# Altium schematic sheet CAN.SchDoc(CAN)
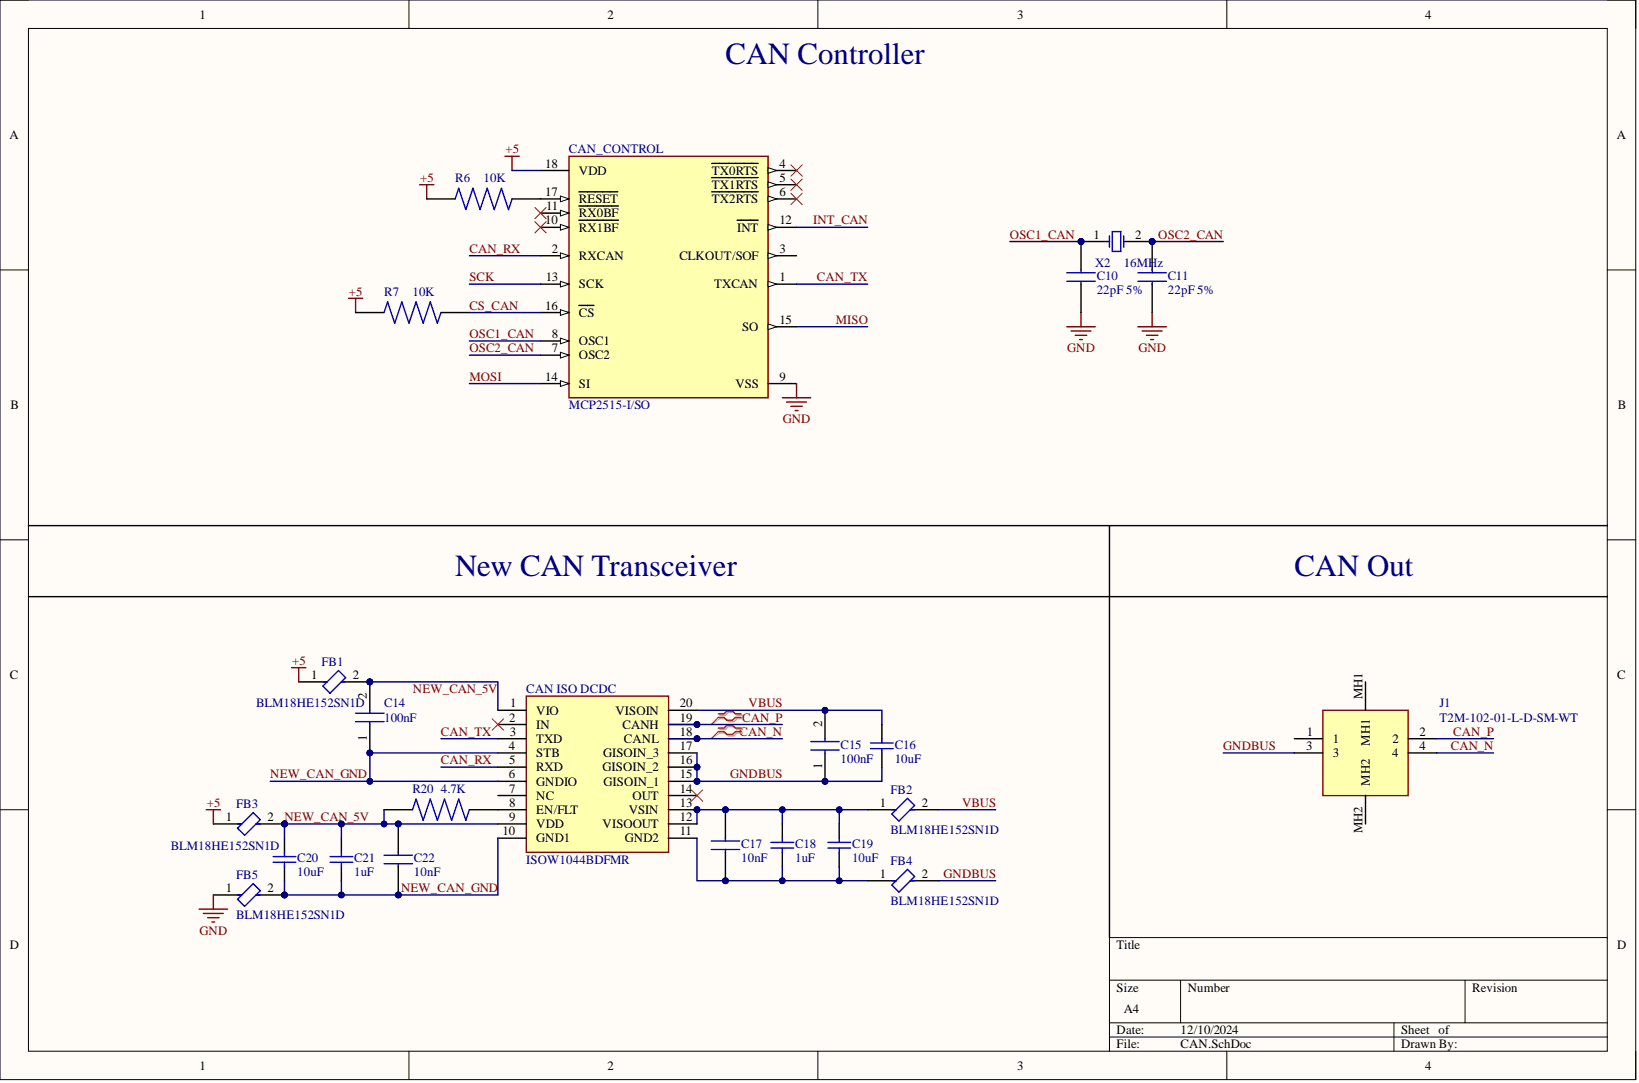
[diagram p0: CAN.SchDoc]

## Parts
C10  22pF 5%
C11  22pF 5%
C14  100nF
C15  100nF
C16  10uF
C17  10nF
C18  1uF
C19  10uF
C20  10uF
C21  1uF
C22  10nF
CAN_ISO_DCDC  ISOW1044BDFMR
CAN_CONTROL  MCP2515-I/SO
FB1  BLM18HE152SN1D
FB2  BLM18HE152SN1D
FB3  BLM18HE152SN1D
FB4  BLM18HE152SN1D
FB5  BLM18HE152SN1D
J1  T2M-102-01-L-D-SM-WT
R6  10K
R7  10K
R20  4.7K
X2  16MHz

## Nets
+5: CAN_CONTROL.18(VDD) FB1.1 FB3.1 R6.1 R7.1
GND: C10.1 C11.1 CAN_CONTROL.9(VSS) FB5.1
CAN_N: CAN_ISO_DCDC.18(CANL) J1.4
CAN_P: CAN_ISO_DCDC.19(CANH) J1.2
CAN_RX: CAN_ISO_DCDC.5(RXD) CAN_CONTROL.2(RXCAN)
CAN_TX: CAN_ISO_DCDC.3(TXD) CAN_CONTROL.1(TXCAN)
CS_CAN: CAN_CONTROL.16(CS) R7.2
GNDBUS: C15.1 C16.1 CAN_ISO_DCDC.15(GISOIN_1) CAN_ISO_DCDC.16(GISOIN_2) CAN_ISO_DCDC.17(GISOIN_3) FB4.2 J1.3
INT_CAN: CAN_CONTROL.12(INT)
MISO: CAN_CONTROL.15(SO)
MOSI: CAN_CONTROL.14(SI)
NEW_CAN_5V: C14.2 C20.2 C21.2 C22.2 CAN_ISO_DCDC.1(VIO) CAN_ISO_DCDC.9(VDD) FB1.2 FB3.2 R20.1
NEW_CAN_GND: C14.1 C20.1 C21.1 C22.1 CAN_ISO_DCDC.4(STB) CAN_ISO_DCDC.6(GNDIO) CAN_ISO_DCDC.10(GND1) FB5.2
OSC1_CAN: C10.2 CAN_CONTROL.8(OSC1) X2.1
OSC2_CAN: C11.2 CAN_CONTROL.7(OSC2) X2.2
SCK: CAN_CONTROL.13(SCK)
VBUS: C15.2 C16.2 CAN_ISO_DCDC.20(VISOIN) FB2.2
NetC17_1: C17.1 C18.1 C19.1 CAN_ISO_DCDC.11(GND2) FB4.1
NetC17_2: C17.2 C18.2 C19.2 CAN_ISO_DCDC.12(VISOOUT) CAN_ISO_DCDC.13(VSIN) FB2.1
NetCAN ISO DCDC_8: CAN_ISO_DCDC.8(EN/FLT) R20.2
NetCAN_CONTROL_17: CAN_CONTROL.17(RESET) R6.2

Not connected: CAN_ISO_DCDC 2(IN) 7(NC) 14(OUT); CAN_CONTROL 3(CLKOUT/SOF) 4(TX0RTS) 5(TX1RTS) 6(TX2RTS) 10(RX1BF) 11(RX0BF); J1 1 MH1 MH2

## Library data of the parts
C10 C11 = CMP-015-000000-3: 885012007053 Multilayer Ceramic Capacitors MLCC - SMD/SMT WCAP-CSGP 22pF 0805 5% 50V MLCC; Manufacturer_Name: Wurth Elektronik; Geometry.Height: 0.7mm; Component Kind: Standard; Datasheet Link: https://katalog.we-online.com/pbs/datasheet/885012007053.pdf; Design Item ID: 885012007053; Manufacturer_Part_Number: 885012007053; Mouser Part Number: 710-885012007053; Mouser Price/Stock: https://www.mouser.co.uk/ProductDetail/Wurth-Elektronik/885012007053?qs=0KOYDY2FL285f7xXUJLtKA%3D%3D; Source: 885012007053.SchLib; Library Name: BumbleBee Autonomous Systems - NUS
C14 C15 = CMP-061-000001-1: Capacitor; Manufacturer_Name: Wurth Elektronik; Manufacturer_Part_Number: 885012207098R; Component Kind: Standard; Datasheet Link: https://www.we-online.com/en/components/products/WCAP-CSGP-50VDC#885012207098R; Design Item ID: 885012207098R; Height: 0.9mm; Source: SamacSys.SchLib; Library Name: BumbleBee Autonomous Systems - NUS
C16 C19 C20 = CMP-00066-1: Cap, Mlcc, X7r, 10Uf, 6.3V, 0805; Tolerance: 10%; Case Code (Imperial): 0805; Case Code (Metric): 2012; Case/Package: 0805; Component Kind: Standard; Length: 0.079inch; Max Operating Temperature: 125°C; Min Operating Temperature: -55°C; Mounting Technology: Surface Mount; Packaging: Cut Tape; RoHS Compliant: Yes; Series: WCAP-CSGP; Thickness: 0.057inch; Value: 10uF; Voltage Rating: 6.3V; Width: 0.049inch; Library Name: BumbleBee Autonomous Systems - NUS
C17 C22 = CMP-012-000000-2: 885382207006 Multilayer Ceramic Capacitors MLCC - SMD/SMT WCAP-CSST 0805 10nF 10% 50VDC; Manufacturer_Name: Wurth Elektronik; Geometry.Height: 1.35mm; Component Kind: Standard; Datasheet Link: https://katalog.we-online.com/pbs/datasheet/885382207006.pdf; Design Item ID: 885382207006; Manufacturer_Part_Number: 885382207006; Mouser Part Number: 710-885382207006; Mouser Price/Stock: https://www.mouser.co.uk/ProductDetail/Wurth-Elektronik/885382207006?qs=0lSvoLzn4L%252BUsoUl2i%252Bhaw%3D%3D; Source: 885382207006.SchLib; Library Name: BumbleBee Autonomous Systems - NUS
C18 C21 = CMP-03913-65419796-1: 885012207022 Cap Cer 1UF 10V X7R 0805; Capacitance: 1µF; Insulation Resistance: 500MΩ; Tolerance: 10%; Case Code (Imperial): 0805; Case Code (Metric): 2012; Case/Package: 0805; Component Kind: Standard; Dielectric: X7R; Height: 1.25mm; Length: 2mm; Library Name: Altium Content Vault; Max Operating Temperature: 125°C; Min Operating Temperature: -55°C; Mount: Surface Mount; NexarPartId: 65419796; Packaging: Cut Tape; RoHS: Compliant; Thickness: 1.3462mm; Voltage Rating: 10V; Voltage Rating (DC): 10V; Width: 1.25mm
CAN_ISO_DCDC = ISOW1044BDFMR: Integrated Circuit; Manufacturer_Name: Texas Instruments; Arrow Part Number: ISOW1044BDFMR; Arrow Price/Stock: https://www.arrow.com/en/products/isow1044bdfmr/texas-instruments?region=nac; Component Kind: Standard; Datasheet Link: https://www.ti.com/lit/ds/symlink/isow1044.pdf?ts=1645295421849&ref_url=https%253A%252F%252Fwww.google.com%252F; Height: 3.55mm; Manufacturer_Part_Number: ISOW1044BDFMR; Mouser Part Number: 595-ISOW1044BDFMR; Mouser Price/Stock: https://www.mouser.co.uk/ProductDetail/Texas-Instruments/ISOW1044BDFMR?qs=Li%252BoUPsLEnsRP1xV2fQwnw%3D%3D
CAN_CONTROL = CMP-00005-1: IC CAN CONTROLLER W/SPI 18SOIC; Data Rate: 1Mbps; Frequency: 40MHz; Interface: SPI,CAN; Max Supply Current: 10mA; Max Supply Voltage: 5.5V; Min Supply Voltage: 2.7V; Number of Drivers: 1; Number of Receive Buffers: 2; Number of Transmit Buffers: 3; Operating Supply Voltage: 5V; Case/Package: SOIC; Component Kind: Standard; Height: 2.31mm; Lead Free: Lead Free; Length: 11.53mm; Max Operating Temperature: 85°C; Min Operating Temperature: -40°C; Mounting Technology: Surface Mount; Nominal Supply Voltage: 5.5V; Number of Receivers: 1; Number of Transceivers: 1; Packaging: Rail/Tube; Pins: 18; Radiation Hardening: No; Reach SVHC Compliant: No; RoHS Compliant: Yes; Series: -; Supply Current: 10mA; Width: 7.49mm; Library Name: BumbleBee Autonomous Systems - NUS
FB1-FB5 = CMP-0686-00368-3: Chip Ferrite Bead, 0603, 1500Ω @ 100MHz, 0.5Ω, 25%, 500mA; DC Resistance (DCR): 500mR; Impedance: 1.5kR; Number of Circuits: 1; Case Code (Imperial): 0603; Case Code (Metric): 1608; Case/Package: 0603; Component Kind: Standard; Core Material: Ferrite; Current Rating: 500mA; DC Current: 500mA; Depth: 0.8mm; Height: 0.8mm; Lead Free: Lead Free; Length: 1.6mm; Library Name: Altium Content Vault; Material: Ferrite; Max Current Rating: 500mA; Max DC Current: 500mA; Max Operating Temperature: 125°C; Military Standard: Not; Min Operating Temperature: -55°C; Number of Lines: 1; Number of Pins: 2; Package Quantity: 1; Packaging: Tape and Reel; Radiation Hardening: No; REACH SVHC: No SVHC; Termination: SMD/SMT; Test Frequency: 100MHz; Tolerance: 25%; Width: 0.8mm
J1 = CMP-062-000000-1: Connector; Manufacturer_Name: SAMTEC; Component Kind: Standard; Datasheet Link: https://suddendocs.samtec.com/prints/t2m-1xx-xx-x-d-xx-xx-x-xx-mkt.pdf?_gl=1*1h8jfhh*_ga*ODgwNjE2ODg0LjE2NDkxNjE4OTQ.*_ga_3KFNZC07WW*MTY4MDE1NTk5OS4xMjYuMC4xNjgwMTU2MDg2LjYwLjAuMA..; Design Item ID: T2M-102-01-L-D-SM-WT; Height: 6.68mm; Manufacturer_Part_Number: T2M-102-01-L-D-SM-WT; Mouser Part Number: 200-T2M10201LDSMWT; Mouser Price/Stock: https://www.mouser.co.uk/ProductDetail/Samtec/T2M-102-01-L-D-SM-WT?qs=PB6%2FjmICvI1RhUpc5sXmcA%3D%3D; Source: SamacSys.SchLib; Library Name: BumbleBee Autonomous Systems - NUS
R6 R7 = CMP-147-000016-1: 10 kOhms Â±1% 0.125W, 1/8W Chip Resistor 0805 _2012 Metric_ Automotive AEC-Q200 Thick Film; MF: Vishay; MP: CRCW080510K0FKEA; Availability: Unavailable; Component Kind: Standard; Component Type: Standard; Design Item ID: CRCW080510K0FKEA; Package: 2012 Vishay; Pin Count: 2; Price: None; SnapEDA_Link: https://www.snapeda.com/parts/CRCW080510K0FKEA/Vishay%20Dale/view-part/47363/?ref=snap; Source: SnapEDAsch.SchLib; Library Name: BumbleBee Autonomous Systems - NUS
R20 = CMP-147-000018-1: RES, THICK FILM, 4K7, 1%, 0.125W, 0805; MP: CRCW08054K70FKEA; Availability: Unavailable; Component Kind: Standard; Component Type: Standard; Design Item ID: CRCW08054K70FKEA; Package: None; Pin Count: 2; Price: None; Source: CRCW08054K70FKEA.SchLib; Library Name: BumbleBee Autonomous Systems - NUS
X2 = CMP-00008-1: Crystal 16MHz 20pF 2-Pin HC49/US SM T/R; ESR: 40R; Frequency Stability: 50ppm; Frequency Tolerance: 30ppm; Component Kind: Standard; ESR (Equivalent Series Resistance): 40R; Frequency: 16MHz; Height: 0.169inch; Length: 0.437inch; Load Capacitance: 20pF; Max Operating Temperature: 70°C; Min Operating Temperature: -20°C; Mounting Technology: Surface Mount; Package Quantity: 1000; Packaging: Tape and Reel; Pins: 2; RoHS Compliant: Yes; Series: ATS-SM; Termination: SMD/SMT; Tolerance: 30ppm; Width: 3.8mm; Library Name: BumbleBee Autonomous Systems - NUS
